# Altium schematic sheet LCD_REV1.2.SchDoc
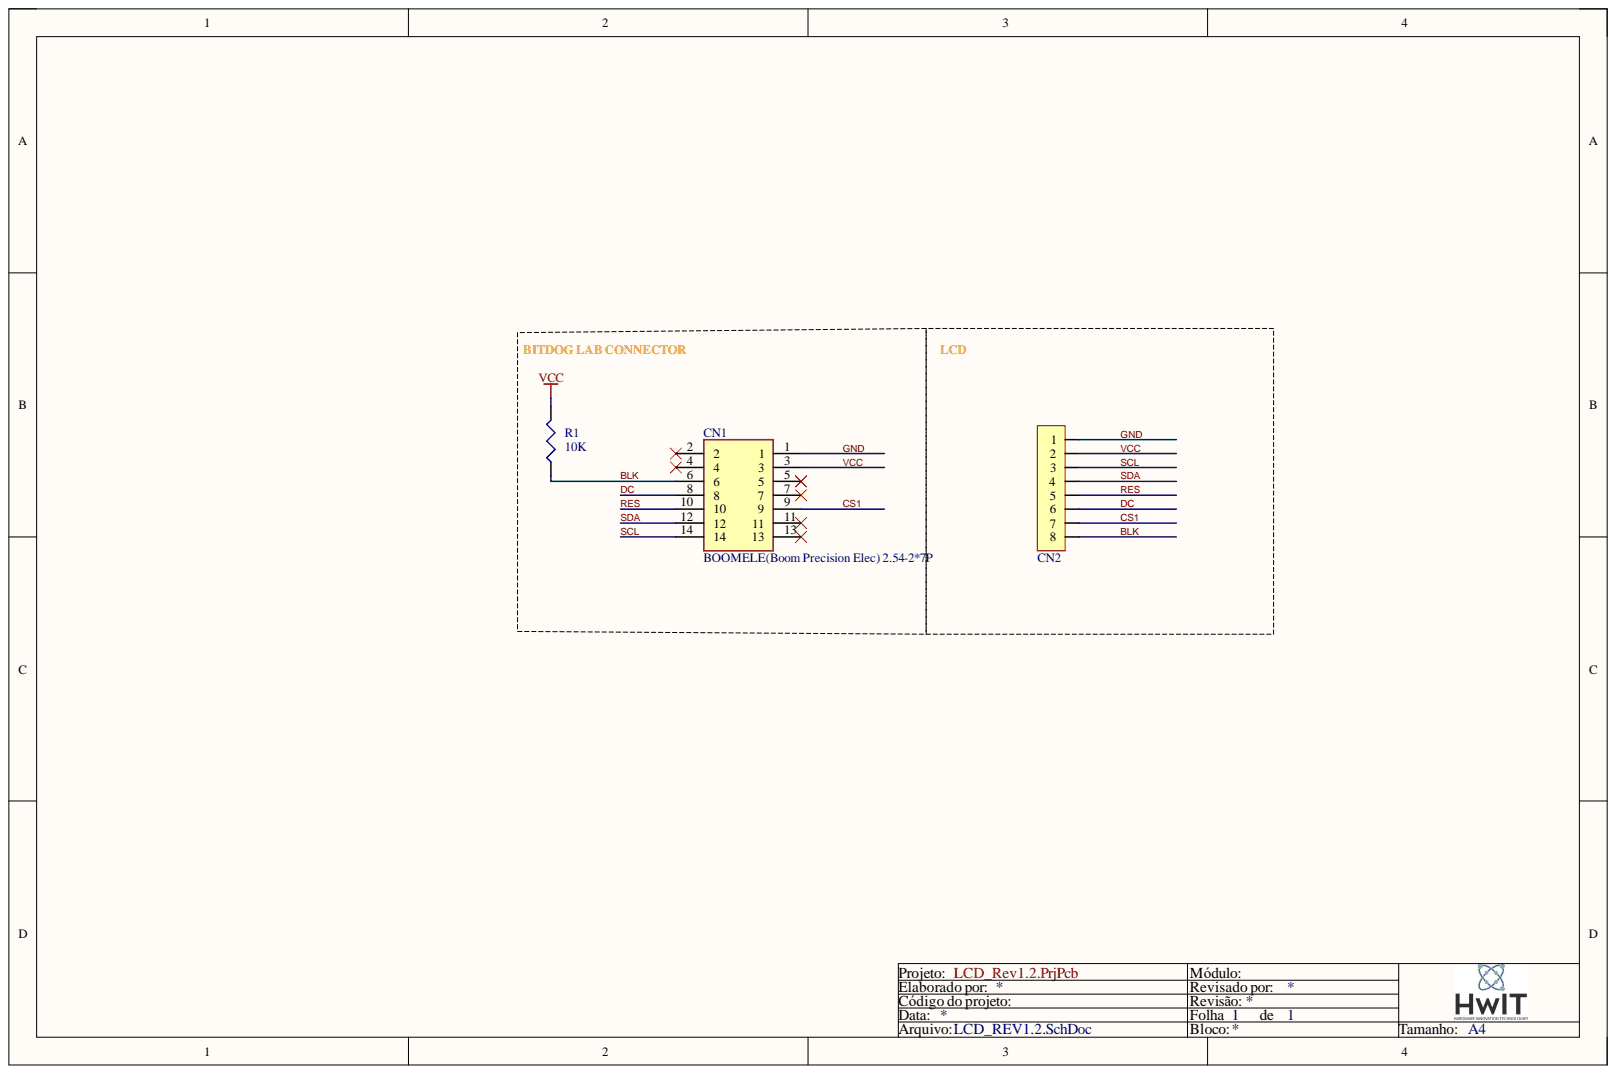
[diagram p0: LCD_REV1.2.SchDoc]

## Parts
CN1  BOOMELE(Boom Precision Elec) 2.54-2*7P  [SHDR14W80P254_2X7_2492X875X1415P]
CN2  HD 1X8 HOR  [HEADER 8 HOR 2.54mm]  (value not drawn)
R1  10K  [R0603]

## Nets
BLK: CN1.6 CN2.8 R1.1
CS1: CN1.9 CN2.7
DC: CN1.8 CN2.6
GND: CN1.1 CN2.1
RES: CN1.10 CN2.5
SCL: CN1.14 CN2.3
SDA: CN1.12 CN2.4
VCC: CN1.3 CN2.2 R1.2

Not connected: CN1 2 4 5 7 11 13

## Library data of the parts
CN1 = 8613-014-10-14-3-4-5-E1LF: 2.54mm Direct Insert Gold Brass 2x7P 7 Simple cow constellation 2.54mm 2 Plugin,P=2.54mm IDC Connectors ROHS; Component Kind: Standard; Height: 14.15mm; Link: https://www.lcsc.com/product-detail/IDC-Connectors_BOOMELE-Boom-Precision-Elec-2-54-2-7P_C11215.html; Manufacturer: BOOMELE; Manufacturer1: BOOMELE(Boom Precision Elec); Manufacturer PN: BOOMELE(Boom Precision Elec) 2.54-2*7P
CN2 = HEADER 1x8 V 2.54MM: CONN HEADER 8POS VERT 2.5MM; Component Kind: Standard; Library Name: Conectores.SchLib
R1 = __ R 0603 __ %: RES SMD 10  KOHM 1% 1/10W 0603; Manufacturer: KOA Speer Electronics, Inc.; Manufacturer PN: RK73H1JTTP1002F; Component Kind: Standard; Digi-Key Part Number: 2019-RK73H1JTTP1002FCT-ND; Library Name: Resistores.SchLib
